# Altium schematic sheet Power.SchDoc(Power)
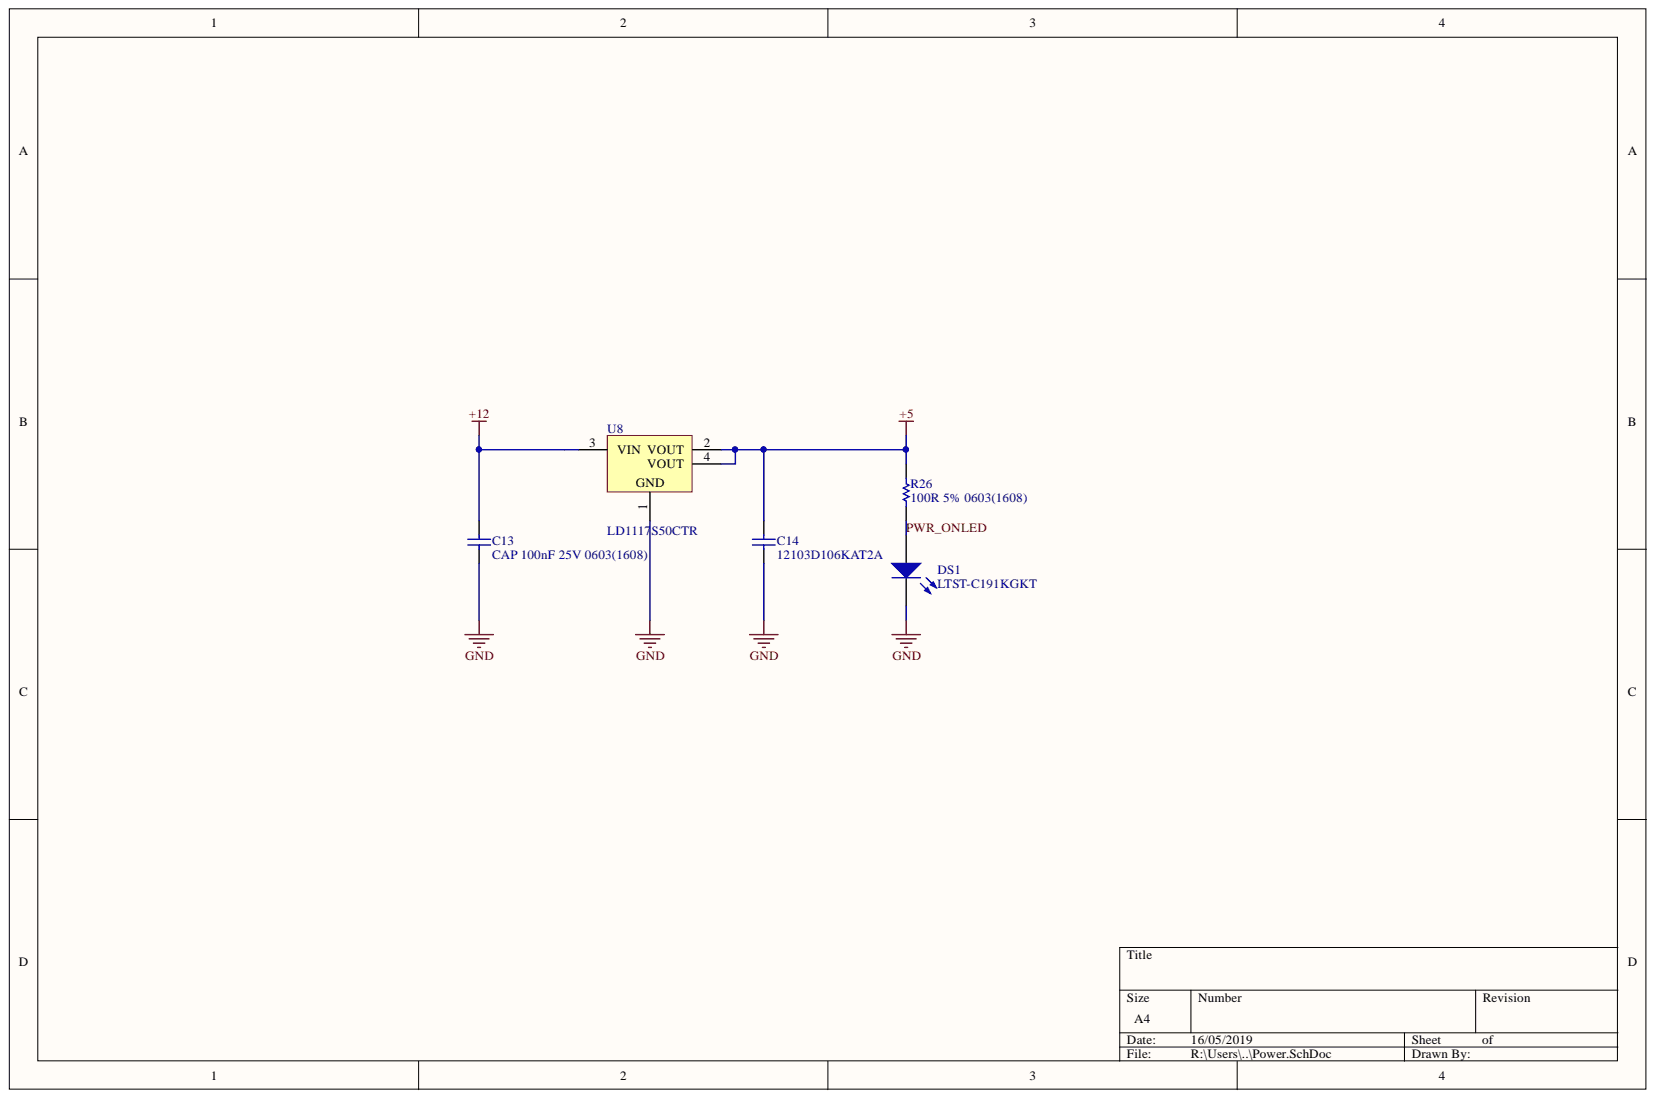
[diagram p0: Power.SchDoc]

## Parts
C13  CAP 100nF 25V 0603(1608)  value 100nF  [CAPC0603(1608)100_N]
C14  12103D106KAT2A  [CAPC3225X279X50LL20T25]
DS1  LTST-C191KGKT  [LITE-ON-LTST-C191KRKT-2_V]
R26  100R 5% 0603(1608)  [RESC0603(1608)_L]
U8  LD1117S50CTR  [SOT-223_N]

## Nets
+5: C14.2 R26.2 U8.2(VOUT) U8.4(VOUT)
+12: C13.2 U8.3(VIN)
GND: C13.1 C14.1 DS1.2 U8.1
PWR_ONLED: DS1.1 R26.1

## Library data of the parts
C13 = CMP-1035-02587-1: CAP 100nF 25V ±5% 0603 (1608 Metric) Thickness 1mm SMD; Tolerance: Â±5%; Case-Metric: 1608; Case-EIA: 0603; Technology: SMT; Rated Voltage: 25 V; Max Thickness: 1 mm; Library Name: Altium Content Vault; Component Kind: Standard
C14 = CMP-2000-05477-1: Chip Capacitor, 10 uF, +/- 10%, 25 V, -55 to 85 degC, 1210 (3225 Metric), RoHS, Tape and Reel; Manufacturer: AVX; Voltage - Rated: 25V; Temperature Coefficient: X5R; Product Training Modules: ComponentSolutionsforSmartMeterApplications; Other Names: 478-1627-6; Online Catalog: X5RDielectric(D); Catalog Drawings: AT2A_1210_2.79; Capacitance: 10µF; Tolerance: ±10%; Thickness (Max): 0.110"(2.79mm); Standard Package: 1; Size / Dimension: 0.126"Lx0.098"W(3.20mmx2.50mm); Series: -; Ratings: -; Packaging: Digi-Reel®; Package Reference: 1210; Package Description: 2-Pin Surface Mount Device, Body 3.2 x 2.5 mm; Package / Case: 1210(3225Metric); Operating Temperature: -55°C~85°C; Mounting Type: SurfaceMount,MLCC; Mounting Technology: SurfaceMount; Manufacturer URL: http://www.avx.com/; Library Name: Altium Content Vault; Lead Style: -; Lead Spacing: -; Height - Seated (Max): -; Features: -; Family: CeramicCapacitors; Datasheet Version: 05/2016; Datasheet URL: http://datasheets.avx.com/cx5r.pdf; Component Kind: Standard; Category: Capacitors; Applications: GeneralPurpose
DS1 = CMP-2000-05198-1: Chip LED, Green, 574 nm, 40 pF, -55 to 85 degC, 2-Pin SMD, RoHS, Tape and Reel; Manufacturer: Lite-On; Viewing Angle: 130deg; Type: Uni-Color; Test Current (It): 20mA; Shape Type: Rectangular; Reverse Voltage: 5V; Rad Hardened: No; Product Length (mm): 1.6mm; Product Height (mm): 0.55mm; Product Depth (mm): 0.8mm; Power Dissipation: 75W; Peak Wavelength: 574nm; Number of Elements: 1; Lum Intensity: 35mcd; High Brightness: Yes; Forward Voltage: 2.4V; Forward Current: 30mA; Emitting Color: YellowGreen; Dominant Wave Length: 571nm; DELETED: Compliant; Chip Material: AlGaInP; Pin Count: 2; Packaging: TapeandReel; Package Type: ChipLed; Operating Temp Range: -55Cto85C; Operating Temperature Classification: Industrial; Mounting: SurfaceMount; Manufacturer URL: http://www.us.liteon.com/; Main Category: ChipLED; Luminous Intensity: 35mcd; Library Name: Altium Content Vault; Lens Dimensions: 1.1X0.8X0.37mm; Datasheet Version: Jan-07; Datasheet URL: http://download.siliconexpert.com/pdfs/2007/08/26/semi_b/manual/lot/ds/ltst-c191kgkt.pdf; Component Kind: Standard
R26 = CMP-1012-00050-1: 100R 0.1W 5% 0603 (1608 Metric)  SMD; Value: 100.00 Ohm; Case-Metric: 1608; Case-EIA: 0603; Tolerance: 5 %; Technology: SMT; Power: 0.1 W; Library Name: Altium Content Vault; Component Kind: Standard
U8 = CMP-0244-00311-1: Fixed Low Drop Positive Voltage Regulator, 5V, 4-Pin SOT-223, Tape and Reel; PackageVersion: 2010; PackageReference: SOT-223; PackageDescription: 4-Pin SOT-223 Package, 6.5 x 3.5 mm Body, 2.3 mm Pitch, 7 mm Lead Span; Library Name: Altium Content Vault; DatasheetVersion: 1/11/2011; ComponentLink2URL: http://www.st.com/internet/com/TECHNICAL_RESOURCES/TECHNICAL_LITERATURE/DATASHEET/CD00000544.pdf; ComponentLink2Description: Datasheet; ComponentLink1URL: http://www.st.com; ComponentLink1Description: Manufacturer URL; Component Kind: Standard
